# Altium schematic sheet LCD.SchDoc(LCD)
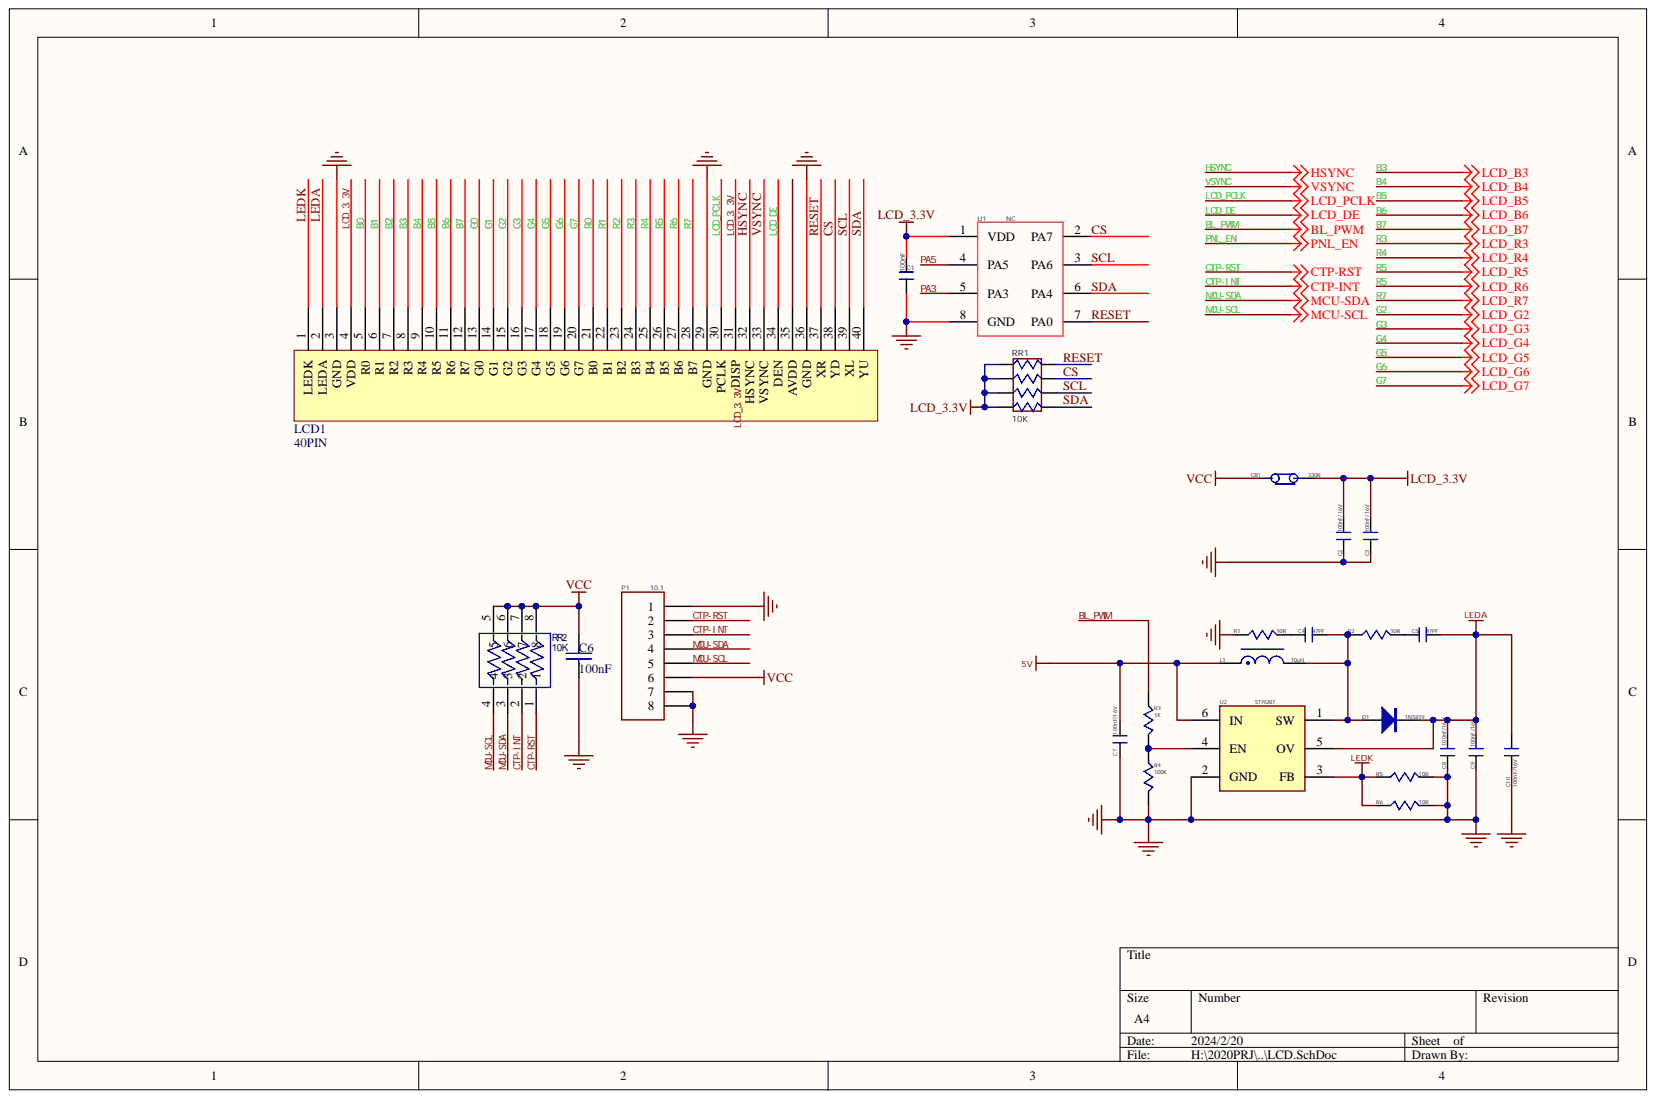
[diagram p0: LCD.SchDoc]

## Parts
C1  100nF  [C0402]
C2  100nF/16V  [C0402]
C3  100nF/16V  [C0402]
C4  47PF  [C0402]
C5  47PF  [C0402]
C6  100nF  [C0402]
C7  100nF/16V  [C0402]
C8  100nF/16V  [C0402]
C9  100nF/16V  [C0402]
C10  100nF/16V  [C0402]
CB1  330R  [L0603]
D1  1N5819  [SOD-123]
L1  10uH  [SWPA5040S]
LCD1  40PIN  [40PIN]
P1  10.1  [TP]
R1  10R  [R0402]
R2  10R  [R0402]
R3  1K  [R0402]
R4  100K  [R0402]
R5  10R  [R0402]
R6  10R  [R0402]
RR1  10K  [8P4R_0603]
RR2  value 10K  [8P4R_0603]  (hidden comment: 22R)
U1  NC  [SO-8]
U2  STI9287  [CSOT23-6]

## Nets
5V: C7.2 L1.1 U2.6(IN)
GND: C1.2 C2.2 C3.2 C6.2 C7.1 C8.1 C9.1 C10.1 LCD1.3(GND) LCD1.29(GND) LCD1.36(GND) P1.1 P1.7 P1.8 R1.1 R4.2 R5.2 R6.2 U1.8(GND) U2.2(GND)
VCC: C6.1 CB1.1 P1.6 RR2.5 RR2.6 RR2.7 RR2.8
B0: LCD1.5(R0)
B1: LCD1.6(R1)
B2: LCD1.7(R2)
B3: LCD1.8(R3)
B4: LCD1.9(R4)
B5: LCD1.10(R5)
B6: LCD1.11(R6)
B7: LCD1.12(R7)
BL_PWM: R3.1
CS: LCD1.38(YD) RR1.7 U1.2(PA7)
CTP-INT: P1.3 RR2.2
CTP-RST: P1.2 RR2.1
G0: LCD1.13(G0)
G1: LCD1.14(G1)
G2: LCD1.15(G2)
G3: LCD1.16(G3)
G4: LCD1.17(G4)
G5: LCD1.18(G5)
G6: LCD1.19(G6)
G7: LCD1.20(G7)
HSYNC: LCD1.32(HSYNC)
LCD_3.3V: C1.1 C2.1 C3.1 CB1.2 LCD1.4(VDD) LCD1.31(DISP) RR1.1 RR1.2 RR1.3 RR1.4 U1.1(VDD)
LCD_DE: LCD1.34(DEN)
LCD_PCLK: LCD1.30(PCLK)
LEDA: C5.1 C8.2 C9.2 C10.2 D1.2 LCD1.2(LEDA) U2.5(OV)
LEDK: LCD1.1(LEDK) R5.1 R6.1 U2.3(FB)
MCU-SCL: P1.5 RR2.4
MCU-SDA: P1.4 RR2.3
PA3: U1.5(PA3)
PA5: U1.4(PA5)
R0: LCD1.21(B0)
R1: LCD1.22(B1)
R2: LCD1.23(B2)
R3: LCD1.24(B3)
R4: LCD1.25(B4)
R5: LCD1.26(B5)
R6: LCD1.27(B6)
R7: LCD1.28(B7)
RESET: LCD1.37(XR) RR1.8 U1.7(PA0)
SCL: LCD1.39(XL) RR1.6 U1.3(PA6)
SDA: LCD1.40(YU) RR1.5 U1.6(PA4)
VSYNC: LCD1.33(VSYNC)
NetC4_1: C4.1 D1.1 L1.2 R2.1 U2.1(SW)
NetC4_2: C4.2 R1.2
NetC5_2: C5.2 R2.2
NetR3_2: R3.2 R4.1 U2.4(EN)
PNL_EN:

Not connected: LCD1 35(AVDD)

## Library data of the parts
C1 = 0805B104K500AT: 贴片电容100nF_0805_25V; 品牌: FH(风华); 封装规格: 0805; Component Kind: Standard; 厂家型号: 0805B104K500AT
C2 C3 = CAPX: Component Kind: Standard; Library Name: JRP7813.SCHLIB
C4 C5 C7-C10 = 0805B104K500AT: 贴片电容100nF/16V_0402; 品牌: FH(风华); 封装规格: 0805; Component Kind: Standard; 厂家型号: 0805B104K500AT
C6 = CAP: Capacitor; Component Kind: Standard
CB1 = CBW201209U331T: 贴片磁珠330R/100MHZ_500MA_0805; 品牌: FH(风华); 封装规格: 0805; Component Kind: Standard; 厂家型号: CBW201209U331T
D1 = SS14: 1N5819_肖特基二极管_SMA; 品牌: ON(安森美); 封装规格: SMA(DO-214AC); Component Kind: Standard; 厂家型号: SS14
L1 = L-YKCD32-220: 贴片功率电感STWNR5020-100MT_5020_10uH; 品牌: 顺络; 封装规格: CD32; Component Kind: Standard; 厂家型号: YKCD32-220
LCD1 = JLT4301A: Component Kind: Standard
P1 = FPC-0.5-6P翻盖: 0.5mm6P翻盖下接连接器; 厂家型号: X05A20L06T; 品牌: XKB(星坤); 封装规格: SMD,P=0.5mm; Component Kind: Standard
R1 R2 R5 R6 = RS-05L8R2JT: 贴片电阻2R_0603; 品牌: FH(风华); 封装规格: 0805; Component Kind: Standard; 厂家型号: RS-05L8R2JT
R3 R4 = RS-05K104JT: 贴片电阻100K_0402; 品牌: FH(风华); 封装规格: 0805; Component Kind: Standard; 厂家型号: RS-05K104JT
RR1 = RR-EXBE10C102J: 贴片排阻33R_0603; 品牌: PANASONIC(松下); 封装规格: 0805_x4; Component Kind: Standard; 厂家型号: EXBE10C102J
RR2 = RP4: PCB DECAL: 8R4R; Component Kind: Standard
U1 = SN8P2501DSG: LicenseMcu; 品牌: SONIX(松翰); 封装规格: SOP-8_150mil; Component Kind: Standard; Library Name: JRP3511B_1.SCHLIB; 厂家型号: SN8P2501DSG
U2 = PT4103B23F: STI9287_升压IC_SOT23-6L; 品牌: PowTech(华润矽威); 封装规格: SOT-23-6; Component Kind: Standard; 厂家型号: PT4103B23F
